# Altium schematic sheet Dog_Tag.SchDoc(Dog_Tag)
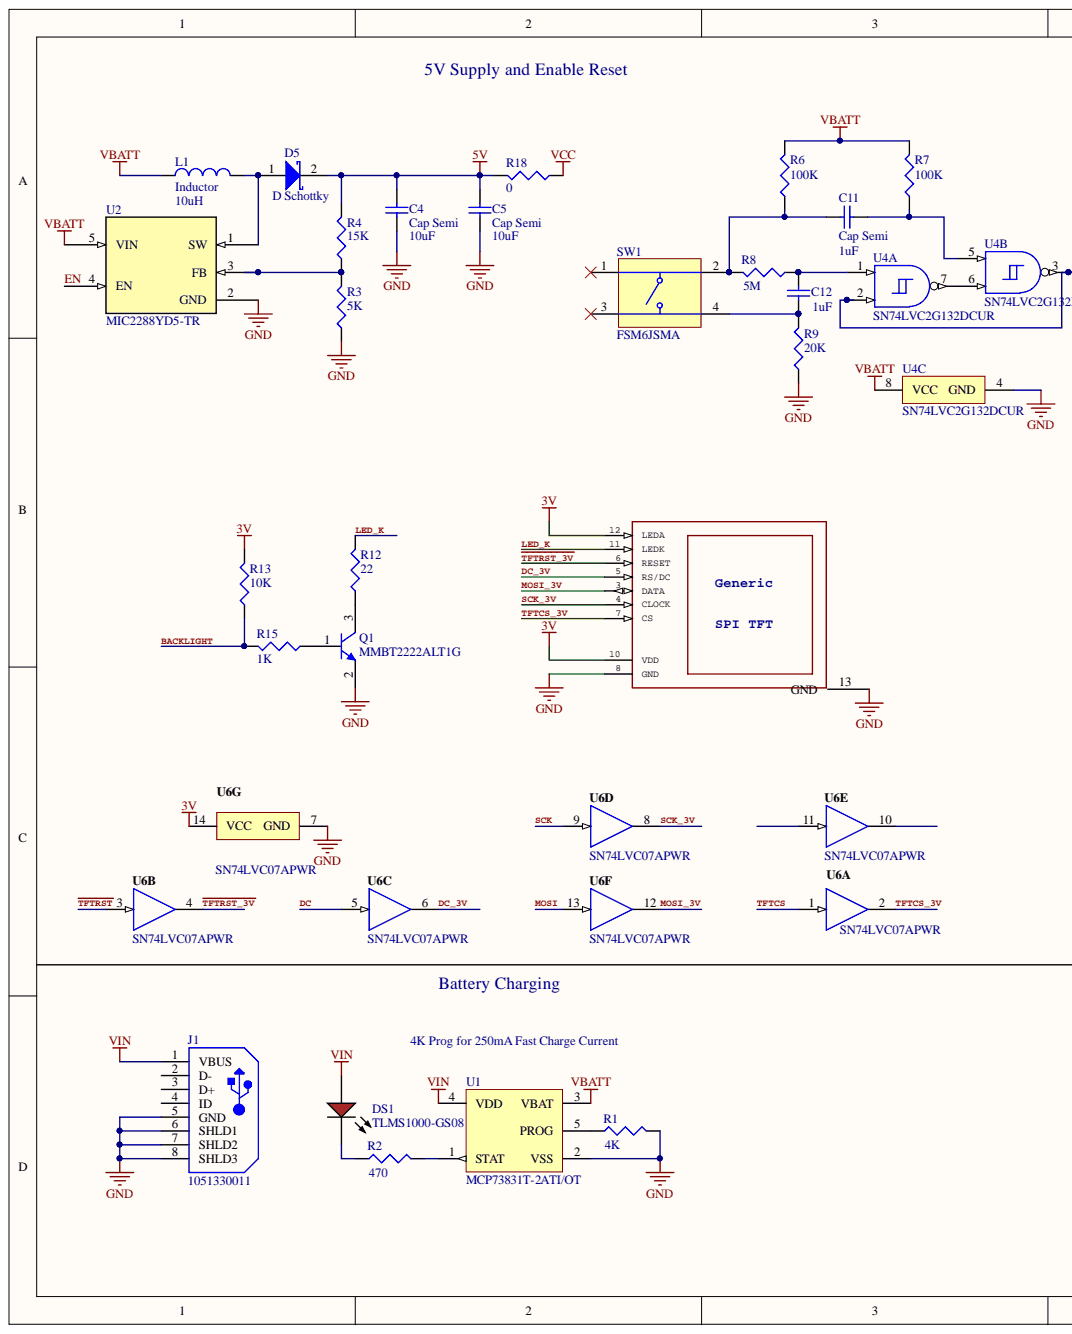
[diagram p0: Dog_Tag.SchDoc, left]
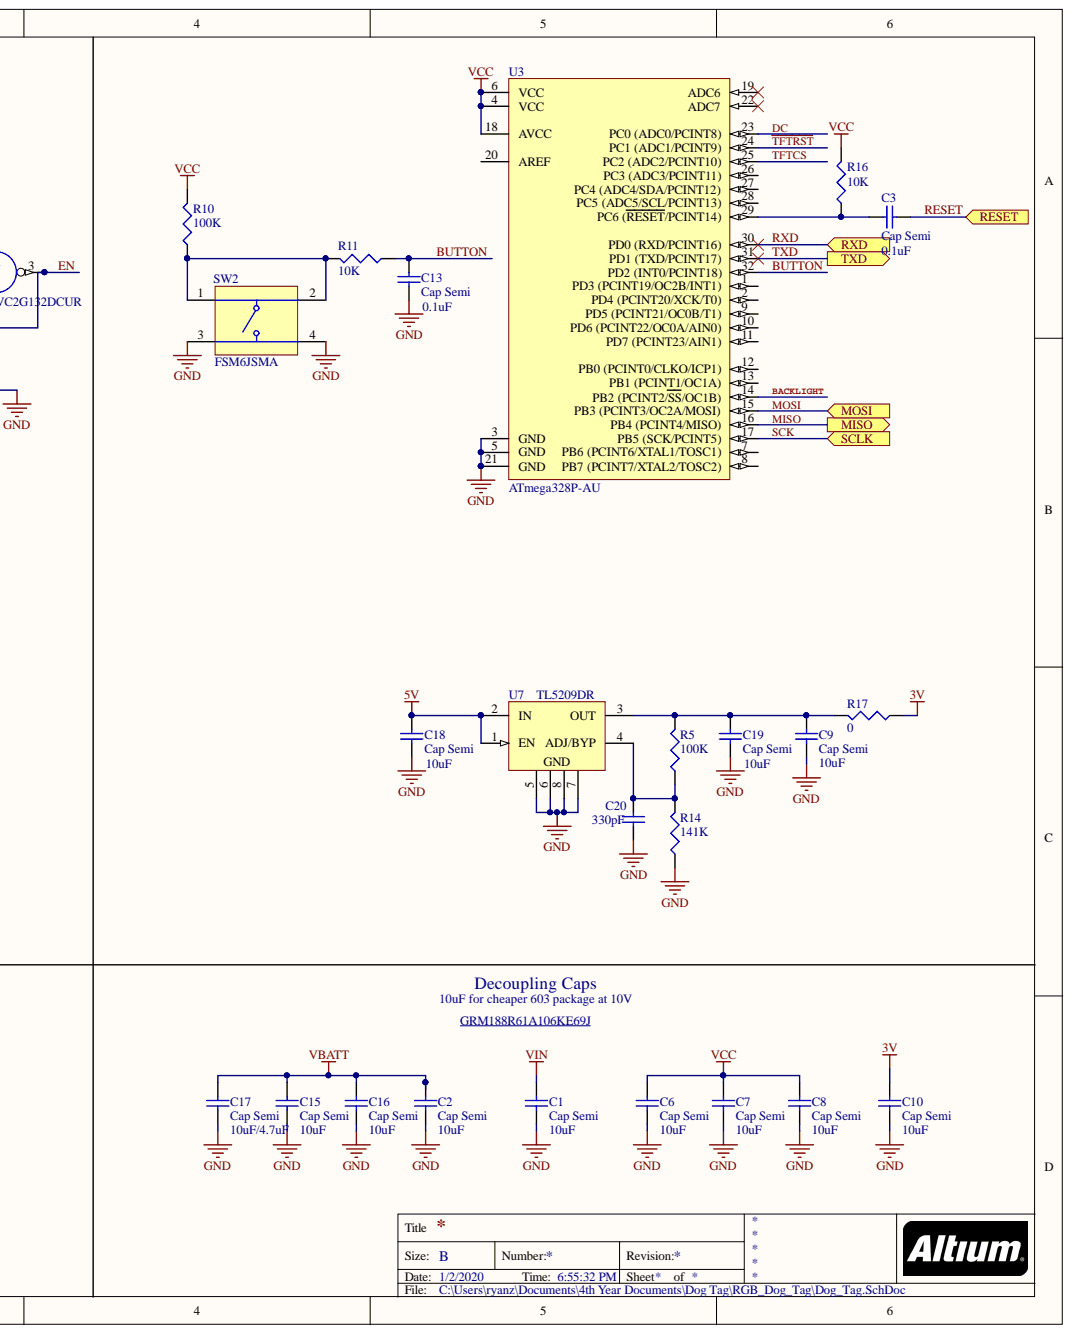
[diagram p1: Dog_Tag.SchDoc, right]

## Parts
C1  Cap Semi  value 10uF  [1608[0603]]
C2  Cap Semi  value 10uF  [1608[0603]]
C3  Cap Semi  value 0.1uF  [1608[0603]]
C4  Cap Semi  value 10uF  [1608[0603]]
C5  Cap Semi  value 10uF  [1608[0603]]
C6  Cap Semi  value 10uF  [1608[0603]]
C7  Cap Semi  value 10uF  [1608[0603]]
C8  Cap Semi  value 10uF  [1608[0603]]
C9  Cap Semi  value 10uF  [1608[0603]]
C10  Cap Semi  value 10uF  [1608[0603]]
C11  Cap Semi  value 1uF  [1608[0603]]
C12  value 1uF  [1608[0603]]  (hidden comment: Cap Semi)
C13  Cap Semi  value 0.1uF  [1608[0603]]
C15  Cap Semi  value 10uF  [1608[0603]]
C16  Cap Semi  value 10uF  [1608[0603]]
C17  Cap Semi  value 10uF/4.7uF  [1608[0603]]
C18  Cap Semi  value 10uF  [1608[0603]]
C19  Cap Semi  value 10uF  [1608[0603]]
C20  value 330pF  [1608[0603]]  (hidden comment: Cap Semi)
D5  D Schottky  [SODFL127P600-8N]
DISP?  SPITFT: SPI TFT  [TFT1.14]  (hidden comment: DISP_LCD_GENERIC_SPI_1.14IN_240X135_WRAPUNDER)
DS1  TLMS1000-GS08  [VISH-TLMS1000_V]
J1  1051330011  [MOLX-1051330011-5_V]
L1  Inductor  value 10uH  [NRS5020T6R8MMGJ]
Q1  MMBT2222ALT1G  [ONSC-SOT-23-3-318-08_V]
R1  value 4K  [J1-0603]  (hidden comment: Res3)
R2  value 470  [J1-0603]  (hidden comment: Res3)
R3  value 5K  [J1-0603]  (hidden comment: Res3)
R4  value 15K  [J1-0603]  (hidden comment: Res3)
R5  value 100K  [J1-0603]  (hidden comment: Res3)
R6  value 100K  [J1-0603]  (hidden comment: Res3)
R7  value 100K  [J1-0603]  (hidden comment: Res3)
R8  value 5M  [J1-0603]  (hidden comment: Res3)
R9  value 20K  [J1-0603]  (hidden comment: Res3)
R10  value 100K  [J1-0603]  (hidden comment: Res3)
R11  value 10K  [J1-0603]  (hidden comment: Res3)
R12  value 22  [J1-0603]  (hidden comment: Res3)
R13  value 10K  [J1-0603]  (hidden comment: Res3)
R14  value 141K  [J1-0603]  (hidden comment: Res3)
R15  value 1K  [J1-0603]  (hidden comment: Res3)
R16  value 10K  [J1-0603]  (hidden comment: Res3)
R17  value 0  [J1-0603]  (hidden comment: Res3)
R18  value 0  [J1-0603]  (hidden comment: Res3)
SW1  FSM6JSMA  [TECO-FSM6JSMA_V]
SW2  FSM6JSMA  [TECO-FSM6JSMA_V]
U1  MCP73831T-2ATI/OT  [SOT-23-OT5_M]
U2  MIC2288YD5-TR  [TSOT-23-5_D5]
U3  ATmega328P-AU  [32A_L]
U4  SN74LVC2G132DCUR  [DCU0008A_L]  (parts A,B,C)
U6  SN74LVC07APWR  [PW0014A_L]  (parts A,B,C,D,E,F,G)
U7  TL5209DR  [D0008A_N]

## Ports
MISO, MOSI, RESET, RXD, SCLK, TXD

## Nets
3V: C10.2 DISP?.10(VDD) DISP?.12(LEDA) R13.2 R17.1 U6.14(VCC)
5V: C4.2 C5.2 C18.2 D5.2 R4.2 R18.2 U7.1(EN) U7.2(IN)
GND: C1.1 C2.1 C4.1 C5.1 C6.1 C7.1 C8.1 C9.1 C10.1 C13.1 C15.1 C16.1 C17.1 C18.1 C19.1 C20.1 DISP?.8(GND) DISP?.13(GND) J1.5(GND) J1.6(SHLD1) J1.7(SHLD2) J1.8(SHLD3) Q1.2 R1.2 R3.1 R9.1 R14.1 SW2.3 SW2.4 U1.2(VSS) U2.2(GND) U3.3(GND) U3.5(GND) U3.21(GND) U4.4(GND) U6.7(GND) U7.5 U7.6 U7.7 U7.8
VBATT: C2.2 C15.2 C16.2 C17.2 L1.1 R6.2 R7.2 U1.3(VBAT) U2.5(VIN) U4.8(VCC)
VCC: C6.2 C7.2 C8.2 R10.2 R16.2 R18.1 U3.4(VCC) U3.6(VCC) U3.18(AVCC)
VIN: C1.2 DS1.1 J1.1(VBUS) U1.4(VDD)
BACKLIGHT: R13.1 R15.2 U3.14(PB2_(PCINT2/SS/OC1B))
BUTTON: C13.2 R11.1 U3.32(PD2_(INT0/PCINT18))
DC: U3.23(PC0_(ADC0/PCINT8)) U6.5
DC_3V: DISP?.5(RS/DC) U6.6
EN: U2.4(EN) U4.2 U4.3
LED_K: DISP?.11(LEDK) R12.2
MISO: U3.16(PB4_(PCINT4/MISO)) ; port MISO
MOSI: U3.15(PB3_(PCINT3/OC2A/MOSI)) U6.13 ; port MOSI
MOSI_3V: DISP?.3(DATA) U6.12
RESET: C3.1 ; port RESET
RXD: U3.30(PD0_(RXD/PCINT16)) ; port RXD
SCK: U3.17(PB5_(SCK/PCINT5)) U6.9 ; port SCLK
SCK_3V: DISP?.4(CLOCK) U6.8
~{TFTRST}: U3.24(PC1_(ADC1/PCINT9)) U6.3
~{TFTRST_3V}: DISP?.6(RESET) U6.4
TFTCS: U3.25 U6.1
TFTCS_3V: DISP?.7(CS) U6.2
TXD: U3.31(PD1_(TXD/PCINT17)) ; port TXD
NetC3_2: C3.2 R16.1 U3.29(PC6_(RESET/PCINT14))
NetC9_2: C9.2 C19.2 R5.2 R17.2 U7.3(OUT)
NetC11_1: C11.1 R7.1 U4.5
NetC11_2: C11.2 R6.1 R8.2 SW1.2
NetC12_1: C12.1 R8.1 U4.1
NetC12_2: C12.2 R9.2 SW1.4
NetC20_2: C20.2 R5.1 R14.2 U7.4(ADJ/BYP)
NetD5_1: D5.1 L1.2 U2.1(SW)
NetDS1_2: DS1.2 R2.1
NetQ1_1: Q1.1 R15.1
NetQ1_3: Q1.3 R12.1
NetR1_1: R1.1 U1.5(PROG)
NetR2_2: R2.2 U1.1(STAT)
NetR3_2: R3.2 R4.1 U2.3(FB)
NetR10_1: R10.1 R11.2 SW2.1 SW2.2
NetU4_6: U4.6 U4.7

Not connected: J1 2(D-) 3(D+) 4(ID); SW1 1 3; U3 1(PD3_(PCINT19/OC2B/INT1)) 2(PD4_(PCINT20/XCK/T0)) 7 8 9(PD5_(PCINT21/OC0B/T1)) 10(PD6_(PCINT22/OC0A/AIN0)) 11(PD7_(PCINT23/AIN1)) 12(PB0_(PCINT0/CLKO/ICP1)) 13(PB1_(PCINT1/OC1A)) 19(ADC6) 20(AREF) 22(ADC7) 26(PC3_(ADC3/PCINT11)) 27(PC4_(ADC4/SDA/PCINT12)) 28(PC5_(ADC5/SCL/PCINT13)); U6 10 11

## Library data of the parts
C1-C10 C12 C13 C15-C20 = Cap Semi: Capacitor (Semiconductor SIM Model); Simulation: CAP; Signal Integrity: Cap Semi; Published: 8-Jun-2000; PackageReference: C1206; PackageDescription: Chip Capacitor; Library Name: Miscellaneous Devices.IntLib; LatestRevisionNote: IPC-7351 Footprint Added.; LatestRevisionDate: 29-May-2009; Component Kind: Standard; Publisher: Altium Limited
C11 = Cap Semi: Capacitor (Semiconductor SIM Model); Value: 1uF; Simulation: CAP; Signal Integrity: Cap Semi; Published: 8-Jun-2000; PackageReference: C1206; PackageDescription: Chip Capacitor; Library Name: Miscellaneous Devices.IntLib; LatestRevisionNote: IPC-7351 Footprint Added.; LatestRevisionDate: 29-May-2009; Component Kind: Standard; Publisher: Altium Limited
D5 = D Schottky: Schottky Diode; Simulation: SKYDIODE; Signal Integrity: D Schottky; Published: 8-Jun-2000; PackageReference: SMB; PackageDescription: DO-214-AA/SMB; 2 C-Bend Leads; Body 5.3 x 3.6 mm, inc. leads (LxW); Library Name: Miscellaneous Devices.IntLib; LatestRevisionNote: Re-released for DXP Platform.; LatestRevisionDate: 17-Jul-2002; ComponentLink1URL: https://www.digikey.com/product-detail/en/on-semiconductor/SS24FL/SS24FLCT-ND/5305093; ComponentLink1Description: Digikey; Component Kind: Standard; Code_JEDEC: DO-214-AA; Publisher: Altium Limited
DISP? = DISP_LCD_GENERIC_SPI_1.14IN_240X135_WRAPUNDER: SymbolName_1: TFT_GENERIC_SPI_VDD; GateName_1: G$1; LibraryName: microbuilder; Library Name: microbuilder.IntLib; Generic: Generic; DeviceSetName: DISP_LCD_GENERIC_SPI; DeviceName: _1.14IN_240X135_WRAPUNDER; Component Kind: Standard
DS1 = CMP-2000-07254-1: Low Current LED, 40 mW, 1.8 V, -40 to 100 degC, 2-Pin SMD (0603), RoHS, Tape and Reel; Manufacturer: Vishay; Wavelength Typ: 636nm; Viewing Angle: 80°; SVHC: ToBeAdvised; Luminous Intensity: 4mcd; Lens Shape: Rectangular; LED Colour: Red; Forward Voltage: 1.8V; Forward Current If: 2mA; Bulb Size: 1.6mmx0.8mm; Automotive Qualification Standard: AEC-Q100; Product Range: -; Packaging: CutTape; Package Reference: TLMS1000; Package Description: 2-Pin Surface Mount Device, Body 1.6 x 0.8 mm; MSL: MSL2-1year; Mounting Technology: SurfaceMount; Manufacturer URL: http://www.vishay.com/; Library Name: Altium Content Vault; LED Mounting: SMD; Datasheet Version: Rev. 1.7, 02/2011; Datasheet URL: https://datasheet.ciiva.com/5798/1698881-5798337.pdf; Component Kind: Standard
J1 = CMP-2000-05241-1: Micro-B USB Vertical Type, -30 to 85 degC, 5-Pin SMD, RoHS, Tape and Reel; Manufacturer: Molex; PCN Packaging: 105133Series03/Oct/2015; PCN Other: 105133Series21/Jul/2015; Other Names: 105133-0011887191097947WM10134TR; Online Catalog: Molex-MicroUSB; Number of Contacts: 5; Gender: Receptacle; Featured Product: USBConnectors; Connector Type: USB-microB; 3D Model: 105133-0011.stp; Standard Package: 500; Specifications: -; Series: 105133; RoHS Information: 105133-0011Cert.ofCompliance; Packaging: Tape&Reel(TR); Mounting Type: SurfaceMountVertical; Manufacturer URL: http://www.molex.com/; Library Name: Altium Content Vault; Ingress Protection: -; Features: BoardGuide; Family: USB,DVI,HDMIConnectors; Datasheet Version: Rev. G, 01/2011; Datasheet URL: http://www.molex.com/pdm_docs/sd/1051330011_sd.pdf; Component Kind: Standard; Category: Connectors,Interconnects
L1 = Inductor: Value: 10uH; Code_IEC: 1005; Simulation: INDUCTOR; Signal Integrity: Inductor; Published: 8-Jun-2000; PackageReference: 0402-A; PackageDescription: Chip Inductor; Library Name: Miscellaneous Devices.IntLib; LatestRevisionNote: IPC-7351 Footprint Added.; LatestRevisionDate: 29-May-2009; Description: Inductor; ComponentLink1URL: https://www.digikey.com/product-detail/en/taiyo-yuden/NRS5020T100MMGJ/587-2409-1-ND/2230275; ComponentLink1Description: Digikey; Component Kind: Standard; Code_JEITA: 0402; Publisher: Altium Limited
Q1 = CMP-1048-00538-1: General Purpose Transistor, NPN Silicon, 3-Pin SOT-23, Pb-Free, Tape and Reel; Manufacturer: On Semiconductor; IC Continuous (A): 0.6; fT Min (MHz): 300; RoHS: TRUE; Polarity: NPN; PartNumber: MMBT2222ALT1G; Packing: Tape and Reel; PackageVersion: Rev. AP, 11/2009; PackageReference: SOT-23-3-318-08; PackageDescription: 3-Pin Small Outline Transistor, Body 2.92 x 1.3 mm, Pitch 0.95 mm; Mounting Technology: Surface Mount; Library Name: Altium Content Vault; DatasheetVersion: Rev. 10; ComponentLink3URL: http://www.onsemi.cn/pub_link/Collateral/318-08.PDF; ComponentLink3Description: Package Specification; ComponentLink2URL: http://www.onsemi.cn/pub_link/Collateral/MMBT2222LT1-D.PDF; ComponentLink2Description: Datasheet; ComponentLink1URL: http://www.onsemi.com/; ComponentLink1Description: Manufacturer URL; Component Kind: Standard
R1-R18 = Res3: Resistor; Simulation: RESISTOR; Signal Integrity: Res; Published: 8-Jun-2000; PackageReference: J1-0603; PackageDescription: Chip Resistor; Library Name: Miscellaneous Devices.IntLib; LatestRevisionNote: IPC-7351 Footprint Added.; LatestRevisionDate: 29-May-2009; Component Kind: Standard; Publisher: Altium Limited
SW1 SW2 = CMP-2000-07503-1: Switch, SPST, 24 V, -35 to 85 degC, 4-Pin SMD, RoHS, Tape and Reel; Manufacturer: TE Connectivity; Voltage Rating (DC): 24V; Switch Travel: 0.25mm; Operating Force: 1.57N; Mechanical Life: 100000Cycles; Max Voltage Rating (DC): 12V; Insulation Resistance: 100MOhm; Illumination Type: Illuminated; Current Rating: 50mA; Case\/Package: SMD/SMT; Actuator Material: Polyamide; Actuator Length: 3.4mm; Width: 6mm; Voltage Rating: 24V; Throw Configuration: SPST; Termination: GullWing; RoHSCompliant: true; REACH SVHC: Unknown; Packaging: LoosePiece; Package Reference: FSM6JSMA; Package Quantity: 750; Package Description: 4-Pin Surface Mount Device, Body 6 x 6 mm, Pitch 4.5 mm; Orientation: Straight; Mounting Technology: SurfaceMount; Mount: SurfaceMount; Min Operating Temperature: -35degC; Max Operating Temperature: 85degC; Manufacturer URL: http://www.te.com/; Library Name: Altium Content Vault; Length: 6mm; Lead Free: LeadFree; ELV: Compliant; Electrical Life: 100000Cycles; Datasheet Version: Rev. V, 02/2015; Datasheet URL: http://www.te.com/commerce/DocumentDelivery/DDEController?Action=showdoc&DocId=Customer+Drawing%7F2-1437565-7%7FV%7Fpdf%7FEnglish%7FENG_CD_2-1437565-7_V.pdf%7F4-1437565-9; Contact Resistance: 100mOhm; Contact Plating: Silver; Contact Material: CopperAlloy; Contact Current Rating: 50mA; Component Kind: Standard
U1 = CMP-0133-00144-1: Miniature Single-Cell, Fully Integrated Li-Ion, Li-Polymer Charge Management Controller, 4.2V, 5-Pin SOT-23, Industrial Temperature, Tape and Reel; PackageVersion: revBB, Aug-2009; PackageReference: SOT-23-OT5; PackageDescription: 5-Lead Plastic Small Outline Transistor (OT) [SOT-23]; Library Name: Altium Content Vault; DatasheetVersion: revE, Sep-2008; Component Kind: Standard; Code_IPC: SOT23-5
U2 = MIC2288YD5-TR: 5V Boost Converter; Vendor: Microchip; Built_By: EMA_Jessica; Value: Value; Type: DEV; RefDes: RefDes; Manufacturer_Part_Number: MIC2288YD5-TR; ComponentLink1URL: https://www.digikey.com/product-detail/en/microchip-technology/MIC2288YD5-TR/576-1729-1-ND/1799425; ComponentLink1Description: Digikey; Component Kind: Standard; Library Name: Dog_Tag.SchLib; Copyright: Copyright (C) 2018 Accelerated Designs. All rights reserved
U3 = CMP-0095-00269-2: 8-bit AVR Microcontroller, 32KB Flash, 1KB EEPROM, 2KB SRAM, 32-pin TQFP, Industrial Grade (-40°C to 85°C); RevisionNote: DatasheetVersion & PackageVersion updated. URLs added.; PackageVersion: revC, Oct-2010; PackageReference: 32A; PackageDescription: 32-Lead, 7x7x1.0 mm Body, 0.8mm Lead Pitch, Thin Profile Plastic Quad Flat Package (TQFP); Library Name: Altium Content Vault; DatasheetVersion: revD, May-2011; ComponentLink3URL: http://www.atmel.com/dyn/resources/prod_documents/8271S.pdf; ComponentLink3Description: ATmega48A/48PA/88A/88PA/168A/168PA/328/328P Summary; ComponentLink2URL: http://www.atmel.com/dyn/resources/prod_documents/doc8271.pdf; ComponentLink2Description: ATmega48A/48PA/88A/88PA/168A/168PA/328/328P Datasheet; ComponentLink1URL: http://www.atmel.com/; ComponentLink1Description: Manufacturer URL; Component Kind: Standard; Code_JEDEC: MS-026-ABA
U4 = CMP-0859-00718-4: Dual 2-Input NAND Gate with Schmitt-Trigger Inputs, DCU0008A, LARGE T&R; Manufacturer: Texas Instruments; Voltage(Nom)(V): 1.8,2.5,3.3,5; VCC(Min)(V): 1.65; VCC(Max)(V): 5.5; tpd @ Nom Voltage(Max)(ns): 16,7.5,6,5; Technology Family: LVC; Schmitt Trigger: Yes; Rating: Catalog; Output Type: CMOS; Output Drive (IOL/IOH)(Max)(mA): 32/-32; Logic: True; Input Type: CMOS/TTL; ICC @ Nom Voltage(Max)(mA): 0.01; F @ Nom Voltage(Max)(Mhz): 150; Bits(#): 2; 3-State Output: No; Sub-Family: AND Gate; PackageReference: DCU0008A; Operating Temperature Range(C): -40 to 125,-40 to 85; Library Name: Altium Content Vault; Generic Part Number: SN74LVC2G132; Gate Type: NAND; DatasheetVersion: SCES547D; Component Kind: Standard
U6 = CMP-0440-01076-3: Hex Buffer/Driver With Open-Drain Outputs, PW0014A, LARGE T&R; Manufacturer: Texas Instruments; Voltage(Nom)(V): 1.8,2.5,2.7,3.3,5; VCC(Min)(V): 1.65; VCC(Max)(V): 5.5; tpd @ Nom Voltage(Max)(ns): 5.6,3.4,3.3,3.6,2.6; Rating: Military; Output Type: LVTTL; Input Type: TTL/CMOS; ICC @ Nom Voltage(Max)(mA): 0.01; F @ Nom Voltage(Max)(Mhz): 110; Bits(#): 10; PackageVersion: http://www.ti.com/lit/ml/mpds360/mpds360.pdf; PackageReference: PW0014A; Operating Temperature Range(C): -40 to 125; Library Name: Altium Content Vault; Generic Part Number: SN74LVC07A; DatasheetVersion: SCAS595U; Component Kind: Standard; Code_JEDEC: MO-153
U7 = CMP-0395-00183-2: Single Output Low Noise LDO, 500 mA, Adjustable 1.3 to 6.5 V Output, 2.5 to 16 V Input, 8-pin SOIC (D), -40 to 125 degC, Green (RoHS & no Sb/Br); Manufacturer: Texas Instruments; Vout Adj(Min)(V): 2.5; Vout Adj(Max)(V): 6.5; Vin(Max)(V): 16; Vdo(Typ)(mV): 500; SubFamily: Single Channel LDO; Rating: Catalog; Iq(Typ)(mA): 8; Iout(Max)(A): 0.5; Accuracy(%): 2; Vin(Min)(V): 2.5; PartNumber: TL5209DR; PackageVersion: 4040047-3/M; PackageReference: D0008A; PackageDescription: 8-Pin Plastic Small Outline, Body 4.9 x 3.9 mm, Pitch 1.27 mm; Mounting Technology: Surface Mount; Library Name: Altium Content Vault; Eco-Plan: Green (RoHS & no Sb/Br); DatasheetVersion: SLVS581A; ComponentLink4URL: Samples URL:http://www.ti.com/product/tl5209#samples; ComponentLink4Description: URL Link1; ComponentLink3URL: http://www.ti.com/litv/pdf/msoi002j; ComponentLink3Description: Package Specification; ComponentLink2URL: http://www.ti.com/general/docs/lit/getliterature.tsp?genericPartNumber=TL5209&fileType=pdf; ComponentLink2Description: Datasheet; ComponentLink1URL: http://www.ti.com/; ComponentLink1Description: Manufacturer URL; Component Kind: Standard; Code_JEDEC: MS-012
